# Altium schematic sheet Buck converter.SchDoc("Buck converter")
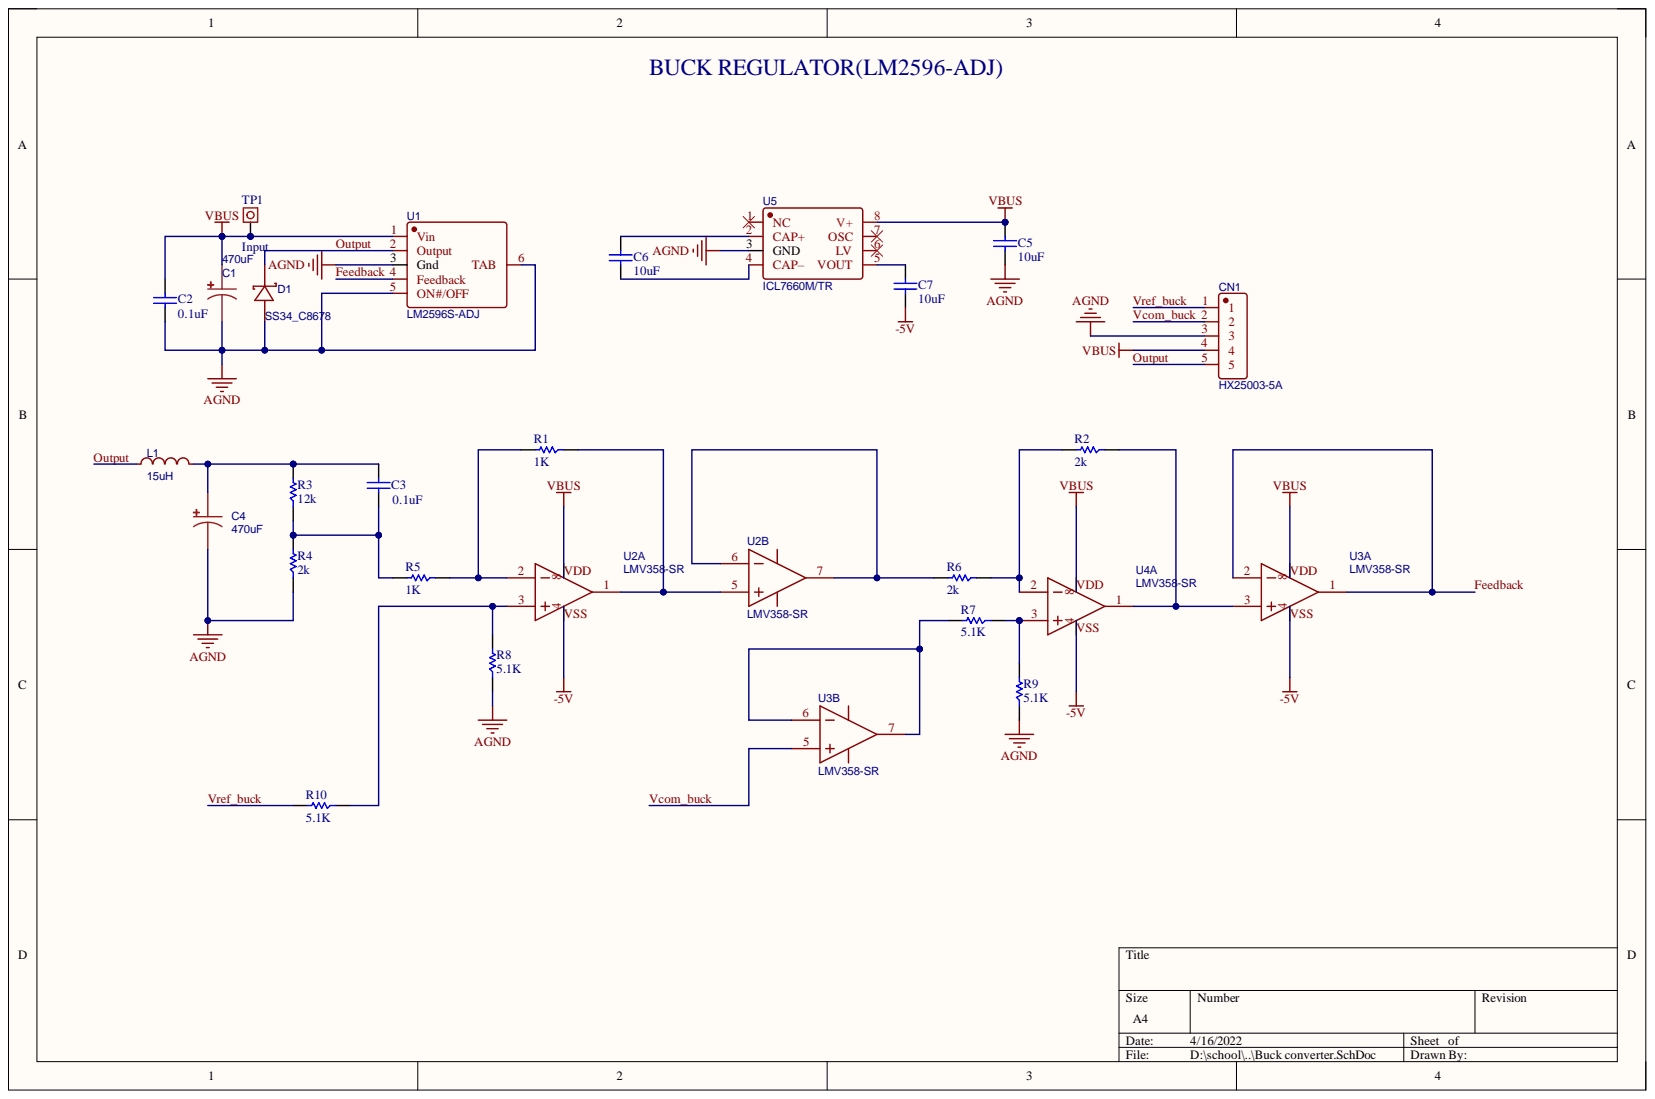
[diagram p0: Buck converter.SchDoc]

## Parts
C1  470uF  [CAP-SMD_BD12.5-L13.0-W13.0-RD]
C2  0.1uF  [0805C]
C3  0.1uF  [0805C]
C4  470uF  [CAP-SMD_BD12.5-L13.0-W13.0-RD]
C5  10uF  [0805C]
C6  10uF  [0805C]
C7  10uF  [0805C]
CN1  HX25003-5A  [CONN-TH_5P-P2.50_HX25003-5A]
D1  SS34_C8678  [DO-214AC_L4.3-W2.7-LS5.3-RD]
L1  15uH  [IND-SMD_L11.5-W10.5_MWSA1004]
R1  1K  [0805]
R2  2k  [0805]
R3  12k  [0805]
R4  2k  [0805]
R5  1K  [0805]
R6  2k  [0805]
R7  5.1K  [0805]
R8  5.1K  [0805]
R9  5.1K  [0805]
R10  5.1K  [0805]
TP1  INPUT  [Testpoin]
U1  LM2596S-ADJ  [TO-263-5_L10.2-W8.9-P1.70-BR]
U2  LMV358-SR  [SOP-8_L4.9-W3.9-P1.27-LS6.0-BL]  (parts A,B)
U3  LMV358-SR  [SOP-8_L4.9-W3.9-P1.27-LS6.0-BL]  (parts A,B)
U4  LMV358-SR  [SOP-8_L4.9-W3.9-P1.27-LS6.0-BL]  (part A)
U5  ICL7660M/TR  [SOP-8_L4.9-W3.9-P1.27-LS6.0-BL]

## Nets
-5V: C7.2 U2.4 U3.4 U4.4
AGND: C1.2 C2.2 C4.2 C5.2 CN1.3 D1.2 R4.1 R8.1 R9.1 U1.3(Gnd) U1.5(ON#/OFF) U1.6(TAB) U5.3(GND)
VBUS: C1.1 C2.1 C5.1 CN1.4 TP1.1 U1.1(Vin) U2.8 U3.8 U4.8 U5.8(V+)
Feedback: U1.4(Feedback) U3.1 U3.2
Output: CN1.5 D1.1 L1.1 U1.2(Output)
Vcom_buck: CN1.2 U3.5
Vref_buck: CN1.1 R10.2
NetC3_1: C3.1 C4.1 L1.2 R3.2
NetC3_2: C3.2 R3.1 R4.2 R5.2
NetC6_1: C6.1 U5.2(CAP+)
NetC6_2: C6.2 U5.4(CAP–)
NetC7_1: C7.1 U5.5(VOUT)
NetR1_1: R1.1 U2.1 U2.5
NetR1_2: R1.2 R5.1 U2.2
NetR2_1: R2.1 U3.3 U4.1
NetR2_2: R2.2 R6.1 U4.2
NetR6_2: R6.2 U2.6 U2.7
NetR7_1: R7.1 R9.2 U4.3
NetR7_2: R7.2 U3.6 U3.7
NetR8_2: R8.2 R10.1 U2.3

Not connected: U5 1(NC) 6(LV) 7(OSC)

## Library data of the parts
C1 C4 = 470uF: BOM_Manufacturer: ROQANG; BOM_Manufacturer Part: RVT1H471M1213; nameAlias: Capacitance; BOM_JLCPCB Part Class: Extended Part; BOM_Supplier: LCSC; BOM_Supplier Part: C90272; Component Kind: Standard; spicePre: C; spiceSymbolName: RVT1H471M1213; Library Name: Portable power supply.IntLib
C2 C3 = C_0.1uf: Capacitor; Reviewed by: RM - 2018/08/05; Component Kind: Standard; Revision: July-2002: Re-released for DXP Platform.; Simulation: CAP; Value: 0.1uF; Library Name: Portable power supply.IntLib
C5-C7 = C_10uf: Capacitor; Reviewed by: RM - 2018/08/05; Component Kind: Standard; Revision: July-2002: Re-released for DXP Platform.; Simulation: CAP; Value: 10uF; Library Name: Portable power supply.IntLib
CN1 = HX25003-5A: BOM_Manufacturer: HX(Zhejiang Yueqing Hongxing Elec); Contributor: LCSC; BOM_JLCPCB Part Class: Extended Part; BOM_Manufacturer Part: HX25003-5A; BOM_Supplier: LCSC; BOM_Supplier Part: C442302; Component Kind: Standard; spicePre: C; spiceSymbolName: HX25003-5A; Library Name: Portable power supply.IntLib
D1 = SS34_C8678: BOM_Manufacturer: MDD; BOM_Manufacturer Part: SS34; BOM_JLCPCB Part Class: Basic Part; BOM_Supplier: LCSC; BOM_Supplier Part: C8678; Component Kind: Standard; spicePre: D; spiceSymbolName: SS34_C8678; Library Name: Portable power supply.IntLib
L1 = 15uH: BOM_Manufacturer: Sunlord; BOM_Manufacturer Part: MWSA1004-150MT; Contributor: LCSC; nameAlias: Inductance; BOM_JLCPCB Part Class: Extended Part; BOM_Supplier: LCSC; BOM_Supplier Part: C151872; Component Kind: Standard; spicePre: L; spiceSymbolName: MWSA1004-150MT; Library Name: Portable power supply.IntLib
R1 R5 = R_1k: Resistor; Reviewed by: RM - 2018/08/05; Component Kind: Standard; Simulation: RES; Value: 1K; Library Name: Portable power supply.IntLib
R2 R4 R6 = R_2k: Resistor; Reviewed by: RM - 2018/08/05; Component Kind: Standard; Simulation: RES; Value: 2k; Library Name: Portable power supply.IntLib
R3 = R_12k: Resistor; Reviewed by: RM - 2018/08/05; Component Kind: Standard; Simulation: RES; Value: 12k; Library Name: Portable power supply.IntLib
R7-R10 = R_5.1k: Resistor; Reviewed by: RM - 2018/08/05; Component Kind: Standard; Simulation: RES; Value: 5.1K; Library Name: Portable power supply.IntLib
TP1 = Test Point: Pad; Component Kind: Standard; Value: Input; Library Name: Portable power supply.IntLib
U1 = LM2596S-ADJ: BOM_Manufacturer: UMW(Youtai Semiconductor Co., Ltd.); Contributor: LCSC; BOM_JLCPCB Part Class: Extended Part; BOM_Manufacturer Part: LM2596S-ADJ; BOM_Supplier: LCSC; BOM_Supplier Part: C347423; Component Kind: Standard; spicePre: U; spiceSymbolName: LM2596S-ADJ; Library Name: Portable power supply.IntLib
U2-U4 = LMV358-SR: BOM_Manufacturer: Gainsil; subpart_no: 2; BOM_JLCPCB Part Class: Extended Part; BOM_Manufacturer Part: LMV358-SR; BOM_Supplier: LCSC; BOM_Supplier Part: C362274; Component Kind: Standard; spicePre: U; spiceSymbolName: LMV358-SR; Library Name: power supply ztc.IntLib
U5 = ICL7660M/TR: BOM_Manufacturer: HGSEMI; Contributor: LCSC; BOM_JLCPCB Part Class: Extended Part; BOM_Manufacturer Part: ICL7660M/TR; BOM_Supplier: LCSC; BOM_Supplier Part: C356724; Component Kind: Standard; spicePre: U; spiceSymbolName: ICL7660M/TR; Library Name: Portable power supply.IntLib
